# Altium schematic sheet Power.SchDoc
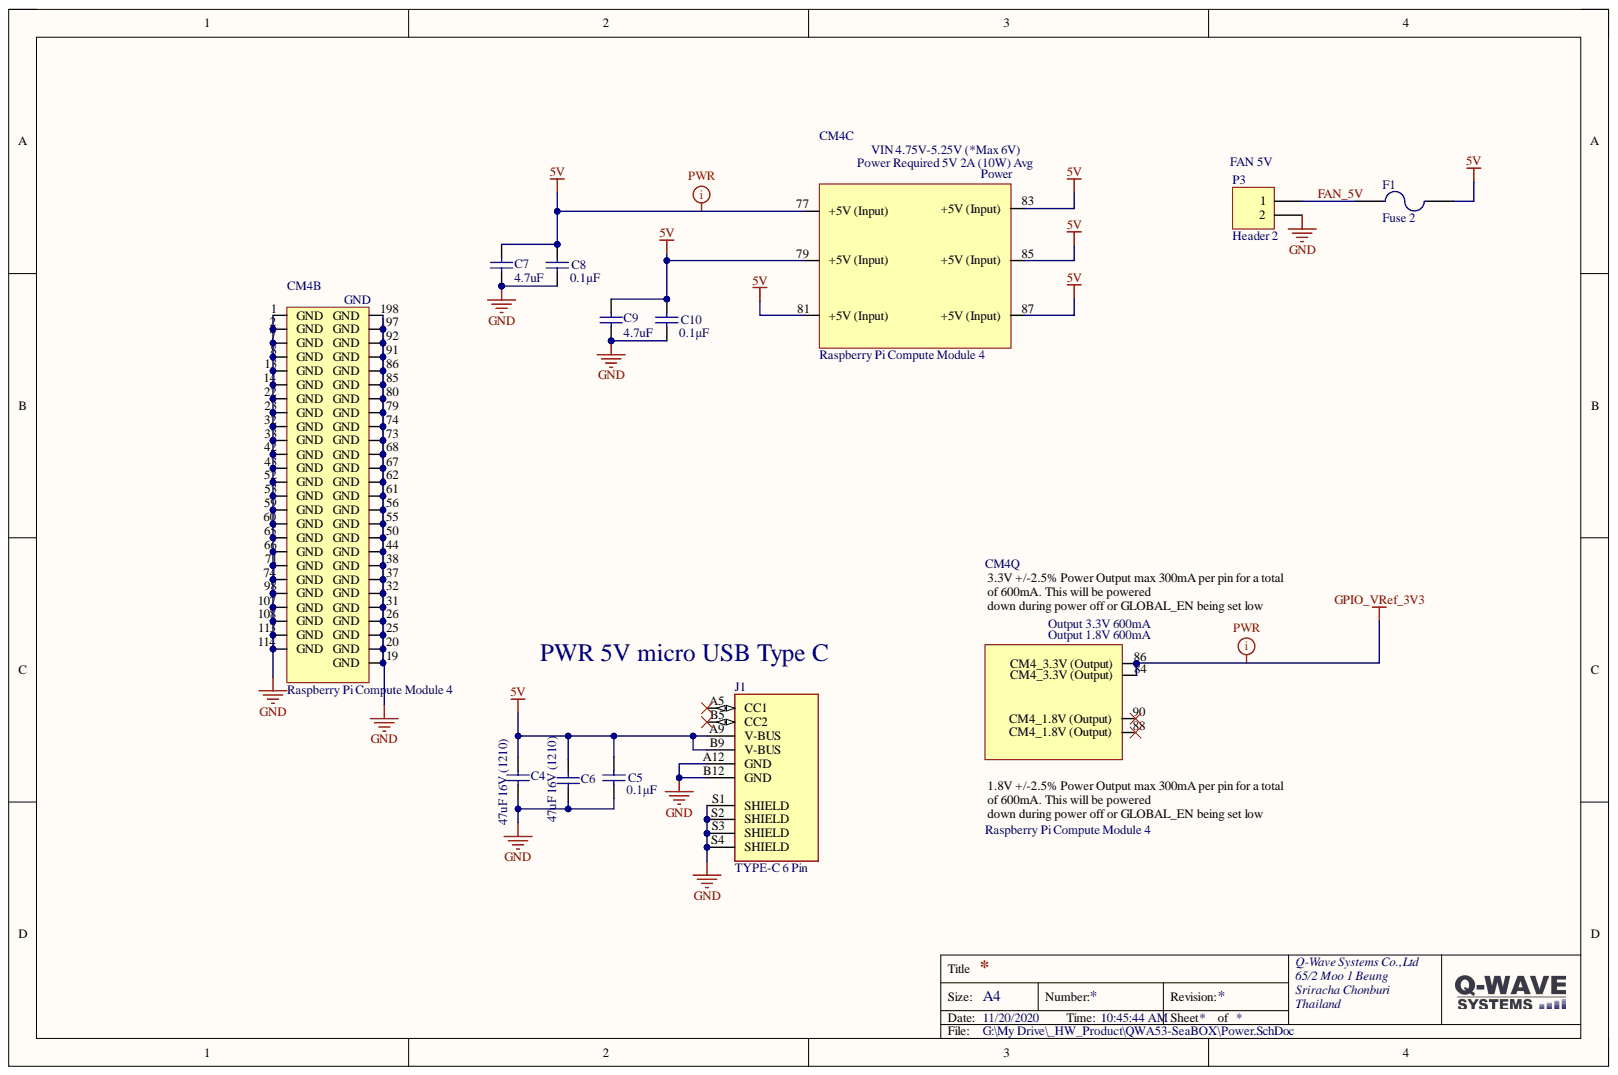
[diagram p0: Power.SchDoc]

## Parts
C4  47uF 16V (1210)  [C 1210]
C5  0.1µF  [CAP_0603]
C6  47uF 16V (1210)  [C 1210]
C7  4.7uF  [CAP_0805]
C8  0.1µF  [CAP_0603]
C9  4.7uF  [CAP_0805]
C10  0.1µF  [CAP_0603]
CM4  Raspberry Pi Compute Module 4  [CM4-F40C-100DS-0.4v_1.5mm_0mm]  (parts B,C,Q)
F1  Fuse 2  [1206]
J1  TYPE-C 6 Pin  [TYPE-C-31-M-17]
P3  Header 2  [HDR1X2]

## Nets
5V: C4.1 C5.1 C6.1 C7.1 C8.1 C9.1 C10.1 CM4.77(+5V_(Input)) CM4.79(+5V_(Input)) CM4.81(+5V_(Input)) CM4.83(+5V_(Input)) CM4.85(+5V_(Input)) CM4.87(+5V_(Input)) F1.2 J1.A9(V-BUS) J1.B9(V-BUS)
GND: C4.2 C5.2 C6.2 C7.2 C8.2 C9.2 C10.2 CM4.1(GND) CM4.2(GND) CM4.7(GND) CM4.8(GND) CM4.13(GND) CM4.14(GND) CM4.22(GND) CM4.23(GND) CM4.32(GND) CM4.33(GND) CM4.42(GND) CM4.43(GND) CM4.52(GND) CM4.53(GND) CM4.59(GND) CM4.60(GND) CM4.65(GND) CM4.66(GND) CM4.71(GND) CM4.74(GND) CM4.98(GND) CM4.107(GND) CM4.108(GND) CM4.113(GND) CM4.114(GND) CM4.119(GND) CM4.120(GND) CM4.125(GND) CM4.126(GND) CM4.131(GND) CM4.132(GND) CM4.137(GND) CM4.138(GND) CM4.144(GND) CM4.150(GND) CM4.155(GND) CM4.156(GND) CM4.161(GND) CM4.162(GND) CM4.167(GND) CM4.168(GND) CM4.173(GND) CM4.174(GND) CM4.179(GND) CM4.180(GND) CM4.185(GND) CM4.186(GND) CM4.191(GND) CM4.192(GND) CM4.197(GND) CM4.198(GND) J1.A12(GND) J1.B12(GND) J1.S1(SHIELD) J1.S2(SHIELD) J1.S3(SHIELD) J1.S4(SHIELD) P3.2
GPIO_VRef_3V3: CM4.84 CM4.86
FAN_5V: F1.1 P3.1

Not connected: CM4 88 90; J1 A5(CC1) B5(CC2)

## Library data of the parts
C4 C6 = Cap: 47uF 16V Ceramic Capacitor X5R 1210; MFG: KEMET; MFG P/N: C0603C475K8PACTU; Component Kind: Standard; Library Name: Miscellaneous Devices.IntLib; Signal Integrity: Cap; Simulation: CAP
C5 C8 C10 = Cap Semi: 0.1µF ±5% 50V Ceramic Capacitor X7R 0603; MFG: KEMET; MFG P/N: C0603C104J5RACTU; Component Kind: Standard; Library Name: Miscellaneous Devices.IntLib; Signal Integrity: Cap Semi; Simulation: CAP
C7 C9 = Cap: 4.7µF ±10% 16V Ceramic Capacitor X5R 0805; MFG: KEMET; MFG P/N: C0603C475K8PACTU; Component Kind: Standard; Library Name: Miscellaneous Devices.IntLib; Signal Integrity: Cap; Simulation: CAP
CM4 = CM4: Component Kind: Standard; Description: Raspberry Pi Compute Module 4; Library Name: CM4.SchLib
F1 = Fuse 2: Fuse; Component Kind: Standard; LatestRevisionDate: 17-Jul-2002; LatestRevisionNote: Re-released for DXP Platform.; Library Name: Miscellaneous Devices.IntLib; PackageDescription: Thru-Hole; 2 Leads; PackageReference: PIN-W2/E2.8; Published: 8-Jun-2000; Simulation: FUSE; Publisher: Altium Limited
J1 = TYPE-C-31-M-17: Manufacturer Korean Hroparts Elec  Mfr.Part # TYPE-C-31-M-17  LCSC Part # C283540; MF: {'manufacturer': u'CUI Devices'}; MP: UJC-HP-3-SMT-TR; Availability: Unavailable; Component Kind: Standard; Library Name: SnapEDAsch.SchLib; Package: None; Price: None
P3 = Header 2: Header, 2-Pin; Component Kind: Standard; LatestRevisionDate: 17-Jul-2002; LatestRevisionNote: Re-released for DXP Platform.; Library Name: Miscellaneous Connectors.IntLib; Signal Integrity: Connector; Publisher: Altium Limited
